# Altium schematic sheet Sheet2.SchDoc(Sheet2)
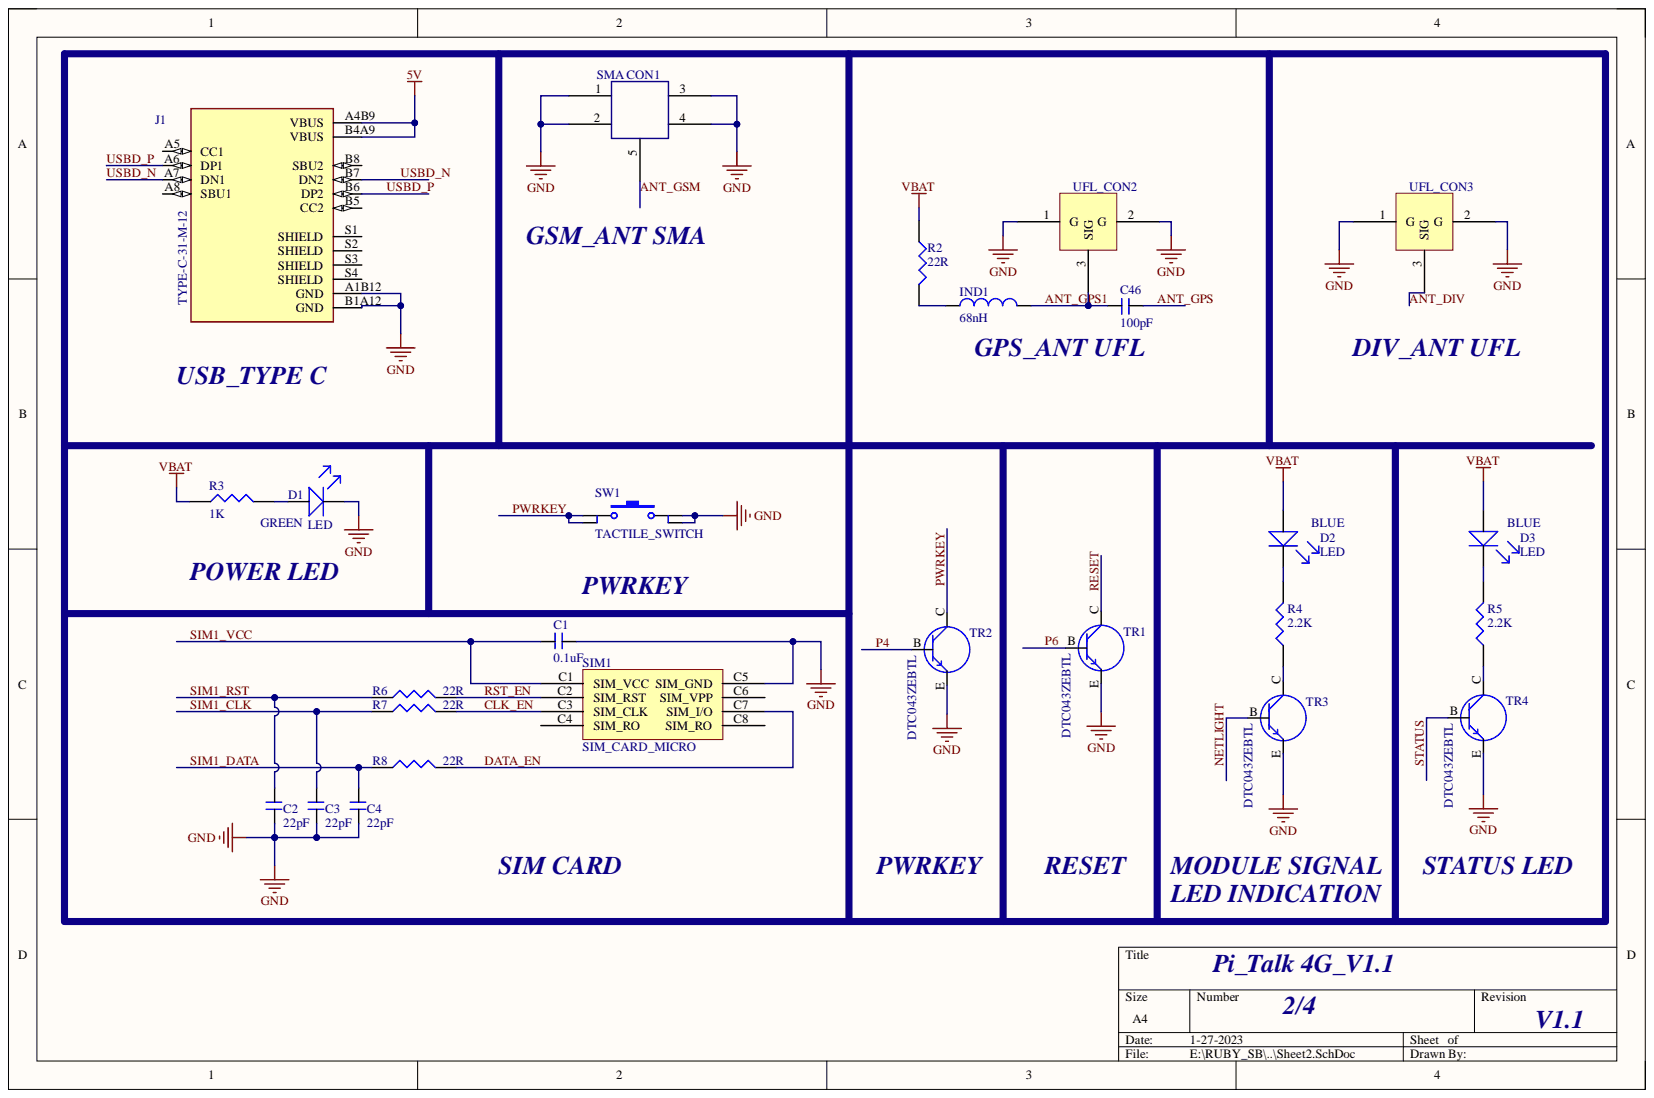
[diagram p0: Sheet2.SchDoc]

## Parts
C1  0.1uF  [CAP_0603_NONPOLAR]
C2  22pF  [CAP_0603_NONPOLAR]
C3  22pF  [CAP_0603_NONPOLAR]
C4  22pF  [CAP_0603_NONPOLAR]
C46  100pF  [CAP_0603_NONPOLAR]
D1  LED  [LED_0603_neg]
D2  LED  [LED_0603_neg]
D3  LED  [LED_0603_neg]
IND1  68nH  [0603]
J1  TYPE-C-31-M-12  [USBC-31-M-12_]
R2  22R  [0603]
R3  1K  [0603]
R4  2.2K  [0603]
R5  2.2K  [0603]
R6  22R  [0603]
R7  22R  [0603]
R8  22R  [0603]
SIM1  SIM_CARD_MICRO  [MICRO SIMCARD]
SMA_CON1  SMA  CONNECTOR  [SMA_CONNECTOR]  (value not drawn)
SW1  TACTILE_SWITCH  [SKRPACE010]
TR1  DTC043ZEBTL  [SOT-416FL]
TR2  DTC043ZEBTL  [SOT-416FL]
TR3  DTC043ZEBTL  [SOT-416FL]
TR4  DTC043ZEBTL  [SOT-416FL]
UFL_CON2  UFL CONNECTOR  [UFL_CONNECTOR]  (value not drawn)
UFL_CON3  UFL CONNECTOR  [UFL_CONNECTOR]  (value not drawn)

## Nets
5V: J1.A4B9(VBUS) J1.B4A9(VBUS)
GND: C1.1 C2.2 C3.2 C4.2 D1.K J1.A1B12(GND) J1.B1A12(GND) SIM1.C5(SIM_GND) SMA_CON1.1 SMA_CON1.2 SMA_CON1.3 SMA_CON1.4 SW1.3 SW1.4 TR1.E TR2.E TR3.E TR4.E UFL_CON2.1 UFL_CON2.2 UFL_CON3.1 UFL_CON3.2
VBAT: D2.A D3.A R2.1 R3.1
ANT_DIV: UFL_CON3.3
ANT_GPS: C46.2
ANT_GPS1: C46.1 IND1.2 UFL_CON2.3
ANT_GSM: SMA_CON1.5
CLK_EN: R7.2 SIM1.C3(SIM_CLK)
DATA_EN: R8.2 SIM1.C7(SIM_I/O)
NETLIGHT: TR3.B
P4: TR2.B
P6: TR1.B
PWRKEY: SW1.1 SW1.2 TR2.C
RESET: TR1.C
RST_EN: R6.2 SIM1.C2(SIM_RST)
SIM1_CLK: C3.1 R7.1
SIM1_DATA: C4.1 R8.1
SIM1_RST: C2.1 R6.1
SIM1_VCC: C1.2 SIM1.C1(SIM_VCC)
STATUS: TR4.B
USBD_N: J1.A7(DN1) J1.B7(DN2)
USBD_P: J1.A6(DP1) J1.B6(DP2)
NetD1_A: D1.A R3.2
NetD2_K: D2.K R4.2
NetD3_K: D3.K R5.2
NetIND1_1: IND1.1 R2.2
NetR4_1: R4.1 TR3.C
NetR5_1: R5.1 TR4.C

Not connected: J1 A5(CC1) A8(SBU1) B5(CC2) B8(SBU2) S1(SHIELD) S2(SHIELD) S3(SHIELD) S4(SHIELD); SIM1 C4(SIM_RO) C6(SIM_VPP) C8(SIM_RO)

## Library data of the parts
C1-C4 C46 = CAP_NONPOLAR: Component Kind: Standard; Library Name: dxp_pasl_lib.IntLib
IND1 = INDUCTOR: Component Kind: Standard; Library Name: dxp_pasl_lib.IntLib
J1 = TYPE-C-31-M-12: USB Connectors 24 Receptacle 1 8.94*7.3mm RoHS; Availability: Unavailable; Component Kind: Standard; Library Name: TYPE-C-31-M-12.SchLib; MP: TYPE-C-31-M-12; Package: None; Price: None
R2-R8 = RES: Component Kind: Standard; Library Name: dxp_pasl_lib.IntLib
SMA_CON1 = SMA CONNECTOR: Component Kind: Standard; Library Name: dxp_pasl_lib.IntLib
TR1-TR4 = DTC043ZEBTL: Pre-Biased Bipolar Transistor (BJT) NPN Pre-Biased 100mA 50V 150mW SOT-416FL Digital Transistors RoHS # C96459; Component Kind: Standard; Library Name: dxp_pasl_lib.IntLib
UFL_CON2 UFL_CON3 = UFL_CONNECTOR: Component Kind: Standard; Library Name: dxp_pasl_lib.IntLib
D1-D3 = LED: Component Kind: Standard; Library Name: dxp_pasl_lib.IntLib
SIM1 = SIM_CARD_MICRO: Component Kind: Standard; Library Name: dxp_pasl_lib.SCHLIB
SW1 = TACTILE_SWITCH: Component Kind: Standard; Library Name: dxp_pasl_lib.IntLib
